# Altium schematic sheet I2S.SchDoc(I2S)
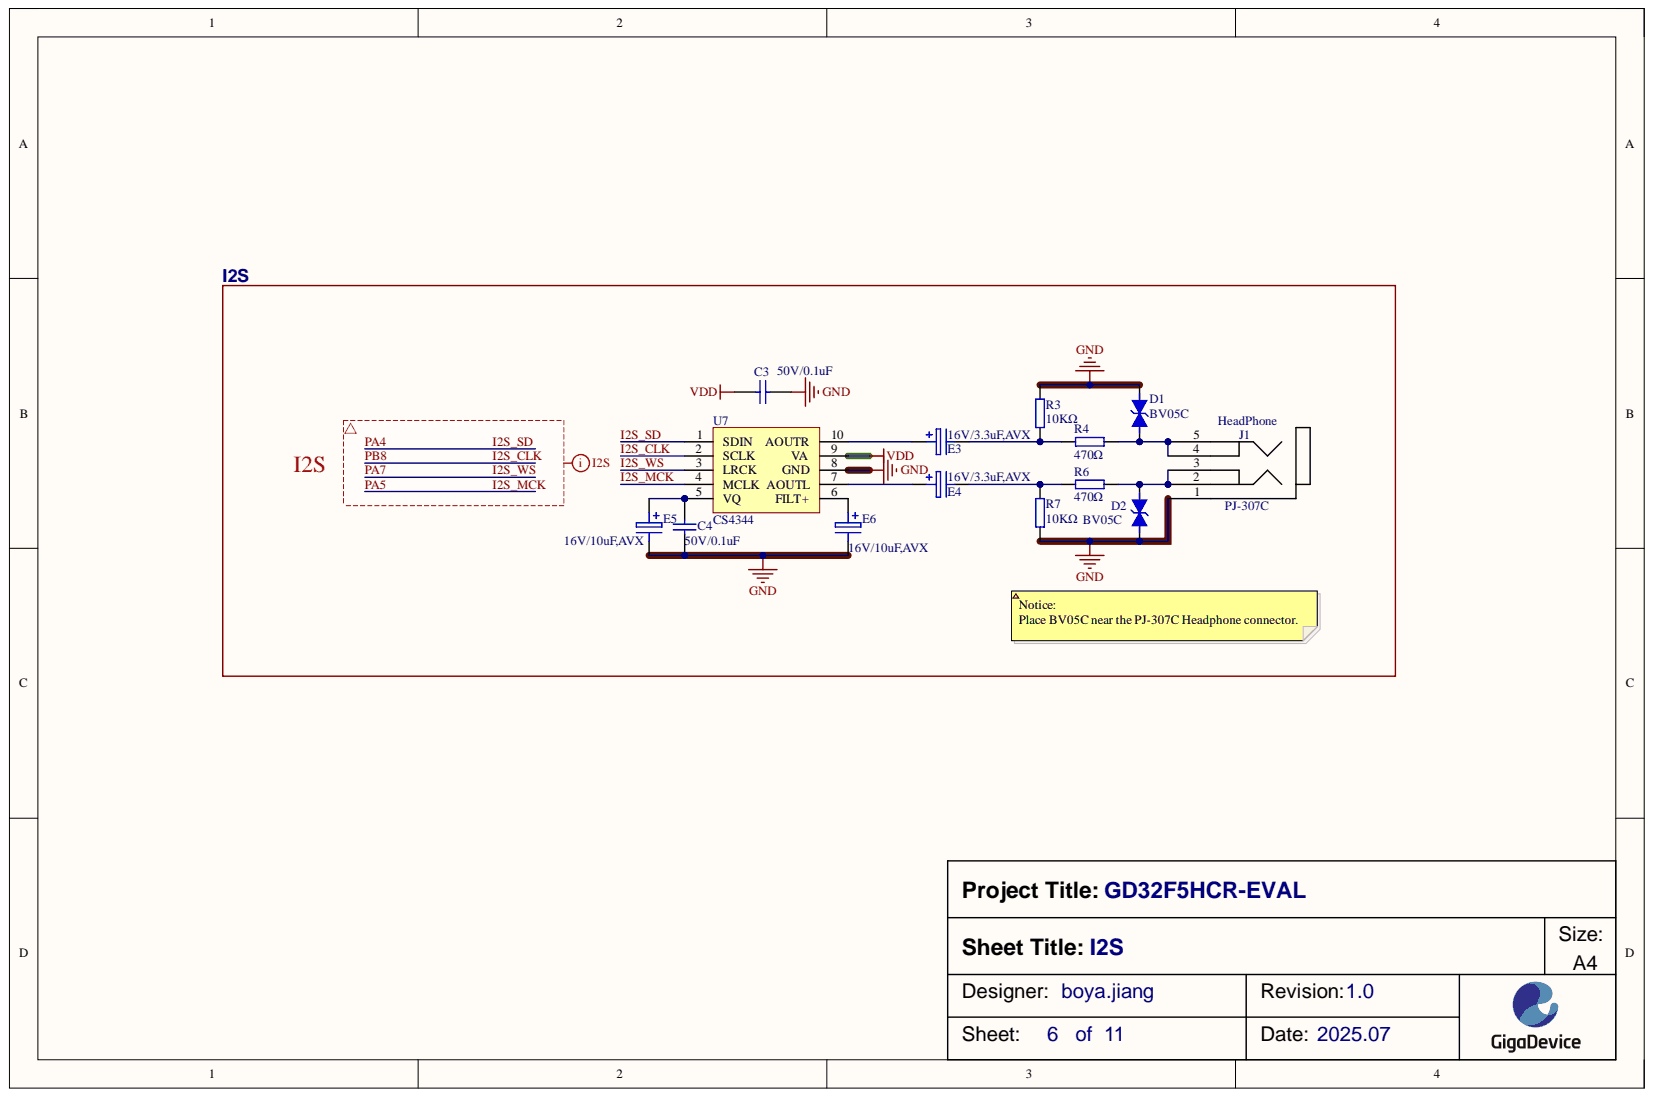
[diagram p0: I2S.SchDoc]

## Parts
C3  50V/0.1uF  [0603-C]
C4  50V/0.1uF  [0603-C]
D1  BV05C  [SOD-323]
D2  BV05C  [SOD-323]
E3  16V/3.3uF,AVX  [SM/C-A-3216]
E4  16V/3.3uF,AVX  [SM/C-A-3216]
E5  16V/10uF,AVX  [SM/C-A-3216]
E6  16V/10uF,AVX  [SM/C-A-3216]
J1  PJ-307C  [Headphone]
R3  10KΩ  [0603-R]
R4  470Ω  [0603-R]
R6  470Ω  [0603-R]
R7  10KΩ  [0603-R]
U7  CS4344  [TSSOP-10 - SMALL]

## Nets
GND: C3.2 C4.2 D1.2 D2.2 E5.2 E6.2 J1.1 R3.2 R7.1 U7.8(GND)
VDD: C3.1 U7.9(VA)
I2S_CLK: U7.2(SCLK)
I2S_MCK: U7.4(MCLK)
I2S_SD: U7.1(SDIN)
I2S_WS: U7.3(LRCK)
NetC4_1: C4.1 E5.1 U7.5(VQ)
NetD1_1: D1.1 J1.4 J1.5 R4.2
NetD2_1: D2.1 J1.2 J1.3 R6.2
NetE3_1: E3.1 U7.10(AOUTR)
NetE3_2: E3.2 R3.1 R4.1
NetE4_1: E4.1 U7.7(AOUTL)
NetE4_2: E4.2 R6.1 R7.2
NetE6_1: E6.1 U7.6(FILT+)

## Library data of the parts
C3 C4 = 50V/0.1uF: 表贴电容; Component Kind: Standard; Library Name: KLTC-电容.SchLib
D1 D2 = BV05C: 表贴双向TVS管; Component Kind: Standard; Library Name: boyajiang.SchLib
E3 E4 = 10V/220uF,AVX: 表贴钽电容; Component Kind: Standard; Library Name: KLTC-电容.SchLib
E5 E6 = 16V/10uF,AVX: 表贴钽电容; Component Kind: Standard; Library Name: KLTC-电容.SchLib
J1 = HeadPhone: 直插耳机座(3.5mm); Component Kind: Standard; Library Name: xufei.SchLib
R3 R7 = 10KΩ: 表贴电阻; Component Kind: Standard; Library Name: KLTC-电阻.SchLib
R4 R6 = 470Ω: 表贴电阻; Component Kind: Standard; Library Name: KLTC-电阻.SchLib
U7 = CS4344: 表贴I2S DA芯片; Component Kind: Standard; Library Name: GD32190R-EVAL.SCHLIB
